# Altium schematic sheet SEPL402 REV1.00.SchDoc("SEPL402 REV1.00")
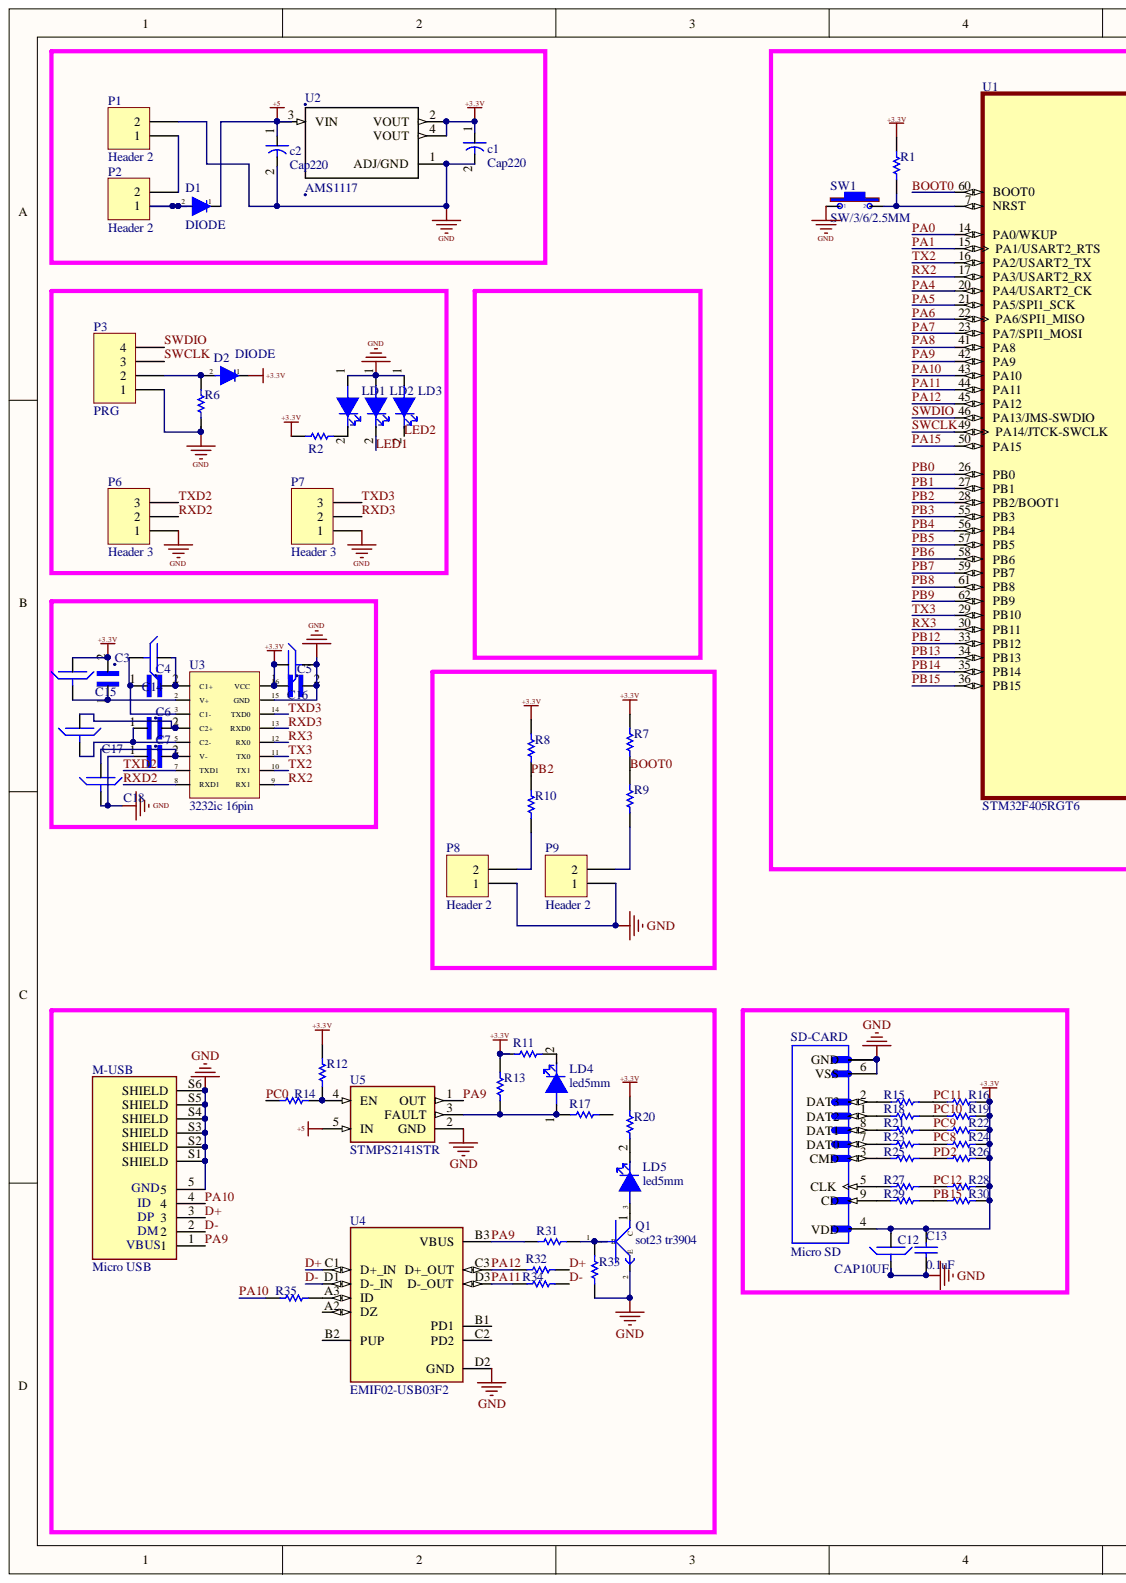
[diagram p0: SEPL402 REV1.00.SchDoc, left]
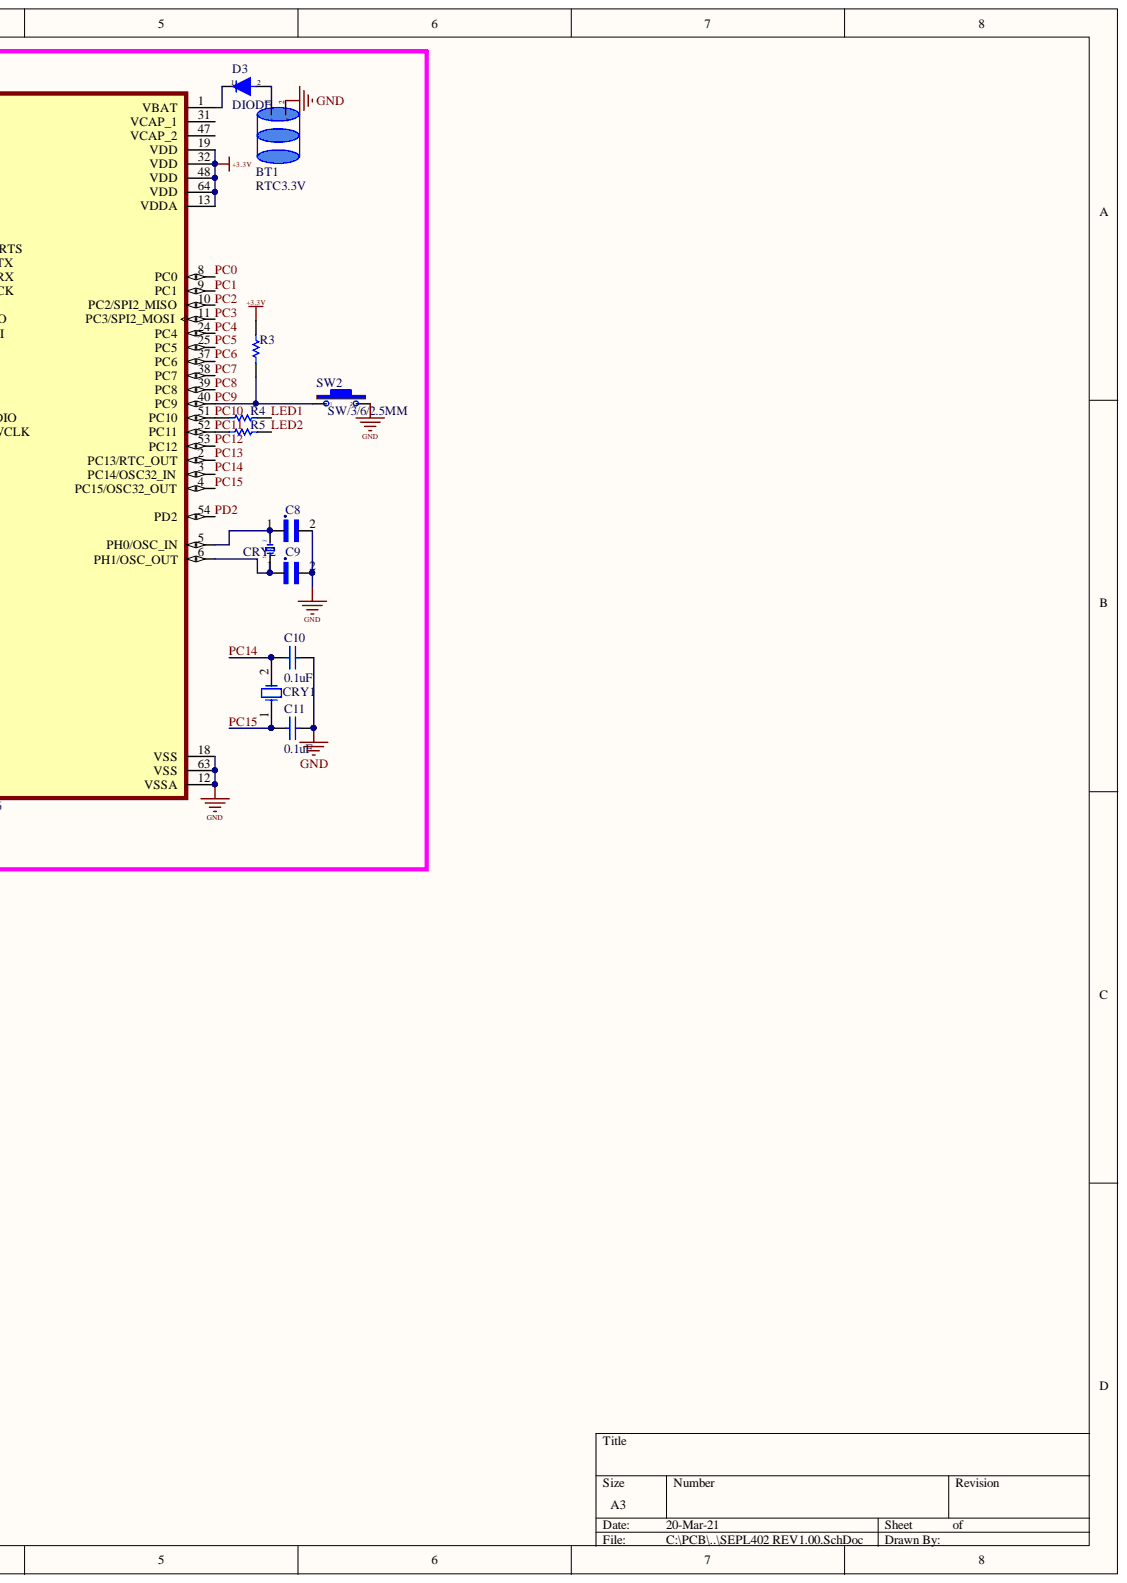
[diagram p1: SEPL402 REV1.00.SchDoc, right]

## Parts
BT1  RTC3.3V  [RTC_BATTERY3.3V]
c1  Cap220  [Cap220]
c2  Cap220  [Cap220]
C3  Cap104pf  [*CAPC2012X130N]  (value not drawn)
C4  Cap104pf  [*CAPC2012X130N]  (value not drawn)
C5  Cap104pf  [*CAPC2012X130N]  (value not drawn)
C6  Cap104pf  [*CAPC2012X130N]  (value not drawn)
C7  Cap104pf  [*CAPC2012X130N]  (value not drawn)
C8  08051A8R2CAT2A  [*CAPC2012X140N]  (value not drawn)
C9  08051A8R2CAT2A  [*CAPC2012X140N]  (value not drawn)
C10  value 0.1uF  [0805]  (hidden comment: Cap)
C11  value 0.1uF  [0805]  (hidden comment: Cap)
C12  CAP10UF  [CAP10UF]
C13  value 0.1uF  [0805]  (hidden comment: Cap)
C14  CAP10UF  [CAP10UF]  (value not drawn)
C15  CAP10UF  [CAP10UF]  (value not drawn)
C16  CAP10UF  [CAP10UF]  (value not drawn)
C17  CAP10UF  [CAP10UF]  (value not drawn)
C18  CAP10UF  [CAP10UF]  (value not drawn)
CRY1  XTAL  [BCY-W2/D3.1]  (value not drawn)
CRY2  CRY  [XTAL]
D1  DIODE  [SS14_DIODE]
D2  DIODE  [SS14_DIODE]
D3  DIODE  [SS14_DIODE]
LD1  led5mm  [led3mm]  (value not drawn)
LD2  led5mm  [led3mm]  (value not drawn)
LD3  led5mm  [led3mm]  (value not drawn)
LD4  led5mm  [led3mm]
LD5  led5mm  [led3mm]
M-USB  Micro USB  [Micro USB Connector]
P1  Header 2  [HDR1X2]
P2  Header 2  [HDR1X2]
P3  PRG  [HDR1X4]
P6  Header 3  [HDR1X3]
P7  Header 3  [HDR1X3]
P8  Header 2  [HDR1X2]
P9  Header 2  [HDR1X2]
Q1  sot23 tr3904  [sot23 tr3904]
R1  1K/0805  [RES805]  (value not drawn)
R2  1K/0805  [RES805]  (value not drawn)
R3  1K/0805  [RES805]  (value not drawn)
R4  1K/0805  [RES805]  (value not drawn)
R5  1K/0805  [RES805]  (value not drawn)
R6  1K/0805  [RES805]  (value not drawn)
R7  1K/0805  [RES805]  (value not drawn)
R8  1K/0805  [RES805]  (value not drawn)
R9  1K/0805  [RES805]  (value not drawn)
R10  1K/0805  [RES805]  (value not drawn)
R11  1K/0805  [RES805]  (value not drawn)
R12  1K/0805  [RES805]  (value not drawn)
R13  1K/0805  [RES805]  (value not drawn)
R14  1K/0805  [RES805]  (value not drawn)
R15  1K/0805  [RES805]  (value not drawn)
R16  1K/0805  [RES805]  (value not drawn)
R17  1K/0805  [RES805]  (value not drawn)
R18  1K/0805  [RES805]  (value not drawn)
R19  1K/0805  [RES805]  (value not drawn)
R20  1K/0805  [RES805]  (value not drawn)
R21  1K/0805  [RES805]  (value not drawn)
R22  1K/0805  [RES805]  (value not drawn)
R23  1K/0805  [RES805]  (value not drawn)
R24  1K/0805  [RES805]  (value not drawn)
R25  1K/0805  [RES805]  (value not drawn)
R26  1K/0805  [RES805]  (value not drawn)
R27  1K/0805  [RES805]  (value not drawn)
R28  1K/0805  [RES805]  (value not drawn)
R29  1K/0805  [RES805]  (value not drawn)
R30  1K/0805  [RES805]  (value not drawn)
R31  1K/0805  [RES805]  (value not drawn)
R32  1K/0805  [RES805]  (value not drawn)
R33  1K/0805  [RES805]  (value not drawn)
R34  1K/0805  [RES805]  (value not drawn)
R35  1K/0805  [RES805]  (value not drawn)
SD-CARD  Micro SD  [MICRO SD/ST-TF-003A]
SW1  SW/3/6/2.5MM  [SMD/TACTILE/SWITCH/3/6/2.5MM]
SW2  SW/3/6/2.5MM  [SMD/TACTILE/SWITCH/3/6/2.5MM]
U1  STM32F405RGT6  [STM32F405RGT6]
U2  AMS1117  [*SOT229P700X180-4N]
U3  3232ic 16pin  [3232ic 14pin]
U4  EMIF02-USB03F2  [EMIF02-USB03F2]
U5  STMPS2141STR  [STMPS2141STR]

## Nets
+3.3V: c1.1 C3.2 C5.1 C12.1 C13.2 C15.1 C16.1 D2.1 R1.1 R2.2 R3.1 R7.1 R8.1 R11.1 R12.1 R13.1 R16.1 R19.1 R20.2 R22.1 R24.1 R26.1 R28.1 R30.1 SD-CARD.4(VDD) U1.13(VDDA) U1.19(VDD) U1.32(VDD) U1.48(VDD) U1.64(VDD) U2.2(VOUT) U2.4(VOUT) U3.16(VCC)
+5: c2.1 D1.1 U2.3(VIN) U5.5(IN)
GND: BT1.2 c1.2 c2.2 C5.2 C7.1 C8.2 C9.2 C10.2 C11.2 C12.2 C13.1 C16.2 C18.2 LD1.1 LD2.1 LD3.1 M-USB.5(GND_5) M-USB.S1(SHIELD) M-USB.S2(SHIELD) M-USB.S3(SHIELD) M-USB.S4(SHIELD) M-USB.S5(SHIELD) M-USB.S6(SHIELD) P1.2 P3.1 P6.1 P7.1 P8.1 P9.1 Q1.2 R6.2 R33.1 SD-CARD.6(VSS) SD-CARD.G1(GND) SD-CARD.G2 SD-CARD.G3 SD-CARD.G4 SW1.1 SW2.2 U1.12(VSSA) U1.18(VSS) U1.63(VSS) U2.1(ADJ/GND) U3.15(GND) U4.D2(GND) U5.2(GND)
BOOT0: R7.2 R9.1 U1.60(BOOT0)
D+: M-USB.3 R32.2 U4.C1(D+_IN)
D-: M-USB.2(DM_2) R34.2 U4.D1(D-_IN)
LED1: LD2.2 R4.1
LED2: LD3.2 R5.1
PA0: U1.14(PA0/WKUP)
PA1: U1.15(PA1/USART2_RTS)
PA4: U1.20(PA4/USART2_CK)
PA5: U1.21(PA5/SPI1_SCK)
PA6: U1.22(PA6/SPI1_MISO)
PA7: U1.23(PA7/SPI1_MOSI)
PA8: U1.41(PA8)
PA9: M-USB.1(VBUS_1) R31.1 U1.42(PA9) U4.B3(VBUS) U5.1(OUT)
PA10: M-USB.4 R35.1 U1.43(PA10)
PA11: R34.1 U1.44(PA11) U4.D3(D-_OUT)
PA12: R32.1 U1.45(PA12) U4.C3(D+_OUT)
PA15: U1.50(PA15)
PB0: U1.26(PB0)
PB1: U1.27(PB1)
PB2: R8.2 R10.1 U1.28(PB2/BOOT1)
PB3: U1.55(PB3)
PB4: U1.56(PB4)
PB5: U1.57(PB5)
PB6: U1.58(PB6)
PB7: U1.59(PB7)
PB8: U1.61(PB8)
PB9: U1.62(PB9)
PB12: U1.33(PB12)
PB13: U1.34(PB13)
PB14: U1.35(PB14)
PB15: R29.1 R30.2 U1.36(PB15)
PC0: R14.1 U1.8(PC0)
PC1: U1.9(PC1)
PC2: U1.10(PC2/SPI2_MISO)
PC3: U1.11(PC3/SPI2_MOSI)
PC4: U1.24(PC4)
PC5: U1.25(PC5)
PC6: U1.37(PC6)
PC7: U1.38(PC7)
PC8: R23.1 R24.2 U1.39(PC8)
PC9: R3.2 R21.1 R22.2 SW2.1 U1.40(PC9)
PC10: R4.2 R18.1 R19.2 U1.51(PC10)
PC11: R5.2 R15.1 R16.2 U1.52(PC11)
PC12: R27.1 R28.2 U1.53(PC12)
PC13: U1.2(PC13/RTC_OUT)
PC14: C10.1 CRY1.2 U1.3(PC14/OSC32_IN)
PC15: C11.1 CRY1.1 U1.4(PC15/OSC32_OUT)
PD2: R25.1 R26.2 U1.54(PD2)
RX2: U1.17(PA3/USART2_RX) U3.9(RX1)
RX3: U1.30(PB11) U3.12(RX0)
RXD2: P6.2 U3.8(RXD1)
RXD3: P7.2 U3.13(RXD0)
SWCLK: P3.3 U1.49(PA14/JTCK-SWCLK)
SWDIO: P3.4 U1.46(PA13/JMS-SWDIO)
TX2: U1.16(PA2/USART2_TX) U3.10(TX1)
TX3: U1.29(PB10) U3.11(TX0)
TXD2: P6.3 U3.7(TXD1)
TXD3: P7.3 U3.14(TXD0)
NetBT1_1: BT1.1 D3.2
NetC3_1: C3.1 C15.2 U3.2(V+)
NetC4_1: C4.1 C14.2 U3.3(C1-)
NetC4_2: C4.2 C14.1 U3.1(C1+)
NetC6_1: C6.1 C17.2 U3.5(C2-)
NetC6_2: C6.2 C17.1 U3.4(C2+)
NetC7_2: C7.2 C18.1 U3.6(V-)
NetC8_1: C8.1 CRY2.2 U1.5(PH0/OSC_IN)
NetC9_1: C9.1 CRY2.1 U1.6(PH1/OSC_OUT)
NetD1_2: D1.2 P2.1
NetD2_2: D2.2 P3.2 R6.1
NetD3_1: D3.1 U1.1(VBAT)
NetLD1_2: LD1.2 R2.1
NetLD4_1: LD4.1 R13.2 R17.1 U5.3(FAULT)
NetLD4_2: LD4.2 R11.2
NetLD5_1: LD5.1 Q1.3
NetLD5_2: LD5.2 R20.1
NetP1_1: P1.1 P2.2
NetP8_2: P8.2 R10.2
NetP9_2: P9.2 R9.2
NetQ1_1: Q1.1 R31.2 R33.2
NetR1_2: R1.2 SW1.2 U1.7(NRST)
NetR12_2: R12.2 R14.2 U5.4(EN)
NetR15_2: R15.2 SD-CARD.2(DAT3)
NetR18_2: R18.2 SD-CARD.1(DAT2)
NetR21_2: R21.2 SD-CARD.8(DAT1)
NetR23_2: R23.2 SD-CARD.7(DAT0)
NetR25_2: R25.2 SD-CARD.3(CMD)
NetR27_2: R27.2 SD-CARD.5(CLK)
NetR29_2: R29.2 SD-CARD.9(CD)
NetR35_2: R35.2 U4.A3(ID)
N00009:

Not connected: R17 2; U1 31(VCAP_1) 47(VCAP_2); U4 A2(DZ) B1(PD1) B2(PUP) C2(PD2)

## Library data of the parts
BT1 = RTC_BATTRY 3.3V: Component Kind: Standard; Library Name: Rakesh_Integrated_Library.IntLib
C3-C7 = VJ0805H103KXBAC: Cap 104pf; MF: Vishay Vitramon; SnapEDA_Link: https://www.snapeda.com/parts/VJ0805H103KXBAC/Vishay%20Vitramon/view-part/?ref=snap; Price: None; Package: 0805 Vishay Vitramon; MP: VJ0805H103KXBAC; Library Name: Integrated_Library1.IntLib; Component Kind: Standard; Availability: Unavailable
C8 C9 = 08051A8R2CAT2A: Capacitor; Ceramic; Cap 8.2 pF; Tol 0.25 pF; Vol-Rtg 100 VDC; SMT; 0805; C0G_NPO_; TR; MF: AVX; SnapEDA_Link: https://www.snapeda.com/parts/08051A8R2CAT2A/AVX/view-part/?ref=snap; Price: None; Package: 0805 AVX; MP: 08051A8R2CAT2A; Library Name: Integrated_Library1.IntLib; Component Kind: Standard; Availability: Unavailable
C10 C11 C13 = Cap: Capacitor; Simulation: CAP; Signal Integrity: Cap; Published: 8-Jun-2000; PackageReference: RAD-0.3; LatestRevisionNote: Re-released for DXP Platform.; LatestRevisionDate: 17-Jul-2002; Component Kind: Standard; Publisher: Altium Limited; Library Name: SEPL-322Rev1.00.IntLib
CRY1 = XTAL: Crystal Oscillator; Simulation: XTAL; Published: 8-Jun-2000; PackageReference: R38; Library Name: mother board.SCHLIB; LatestRevisionNote: Re-released for DXP Platform.; LatestRevisionDate: 17-Jul-2002; Component Kind: Standard; Publisher: Altium Limited
CRY2 = XTAL: Component Kind: Standard; Library Name: Rakesh_Integrated_Library.IntLib
D1-D3 = SS14_DIODE: Component Kind: Standard; Library Name: Rakesh_Integrated_Library.IntLib
M-USB = Micro USB Connector: Component Kind: Standard; Library Name: Rakesh_Integrated_Library.IntLib
P1 P2 P8 P9 = Header 2: Header, 2-Pin; Signal Integrity: Connector; Library Name: Miscellaneous Connectors.IntLib; LatestRevisionNote: Re-released for DXP Platform.; LatestRevisionDate: 17-Jul-2002; Component Kind: Standard; Publisher: Altium Limited
P3 = Header 4: Header, 4-Pin; Signal Integrity: Connector; Library Name: Miscellaneous Connectors.IntLib; LatestRevisionNote: Re-released for DXP Platform.; LatestRevisionDate: 17-Jul-2002; Component Kind: Standard; Publisher: Altium Limited
P6 P7 = Header 3: Header, 3-Pin; Signal Integrity: Connector; Library Name: Miscellaneous Connectors.IntLib; LatestRevisionNote: Re-released for DXP Platform.; LatestRevisionDate: 17-Jul-2002; Component Kind: Standard; Publisher: Altium Limited
R1-R35 = Res1: Resistor; Value: 1K; Simulation: RESISTOR; Signal Integrity: Res; Published: 8-Jun-2000; PackageReference: AXIAL-0.3; Library Name: Miscellaneous Devices.IntLib; LatestRevisionNote: Re-released for DXP Platform.; LatestRevisionDate: 17-Jul-2002; Component Kind: Standard; Publisher: Altium Limited
SD-CARD = MICRO SD/ST-TF-003A: Component Kind: Standard; Library Name: Rakesh_Integrated_Library.IntLib
SW1 SW2 = SMD/TACTILE/SWITCH/3/6/2.5MM: Library Name: Integrated_Library2.IntLib; Component Kind: Standard
U2 = AMS1117: 1A LOW DROPOUT VOLTAGE REGULATOR; MF: Seeed Technology; SnapEDA_Link: https://www.snapeda.com/parts/AMS1117/Seeed%20Technology%20Co.%2C%20Ltd/view-part/?ref=snap; Price: None; Package: SOT-223 Seeed Technology; MP: AMS1117; Library Name: Integrated_Library1.IntLib; Component Kind: Standard; Availability: Unavailable
U3 = 3232ic 14pin: Library Name: Integrated_Library1.IntLib; Component Kind: Standard
c1 c2 = Cap220: Library Name: Integrated_Library1.IntLib; Component Kind: Standard
C12 C14-C18 = CAP10UF: Library Name: Integrated_Library1.IntLib; Component Kind: Standard
LD1-LD5 = led5mm: Library Name: Integrated_Library1.IntLib; Component Kind: Standard
Q1 = sot23 tr3904: Library Name: Integrated_Library1.IntLib; Component Kind: Standard
U1 = STM32F405RGT6: Component Kind: Standard; Library Name: Rakesh_Integrated_Library.IntLib
U4 = EMIF02-USB03F2: Component Kind: Standard; Library Name: Rakesh_Integrated_Library.IntLib
U5 = STMPS2141STR: Component Kind: Standard; Library Name: Rakesh_Integrated_Library.IntLib
